# Altium schematic sheet sdflowmetercpu.SchDoc(sdflowmetercpu)
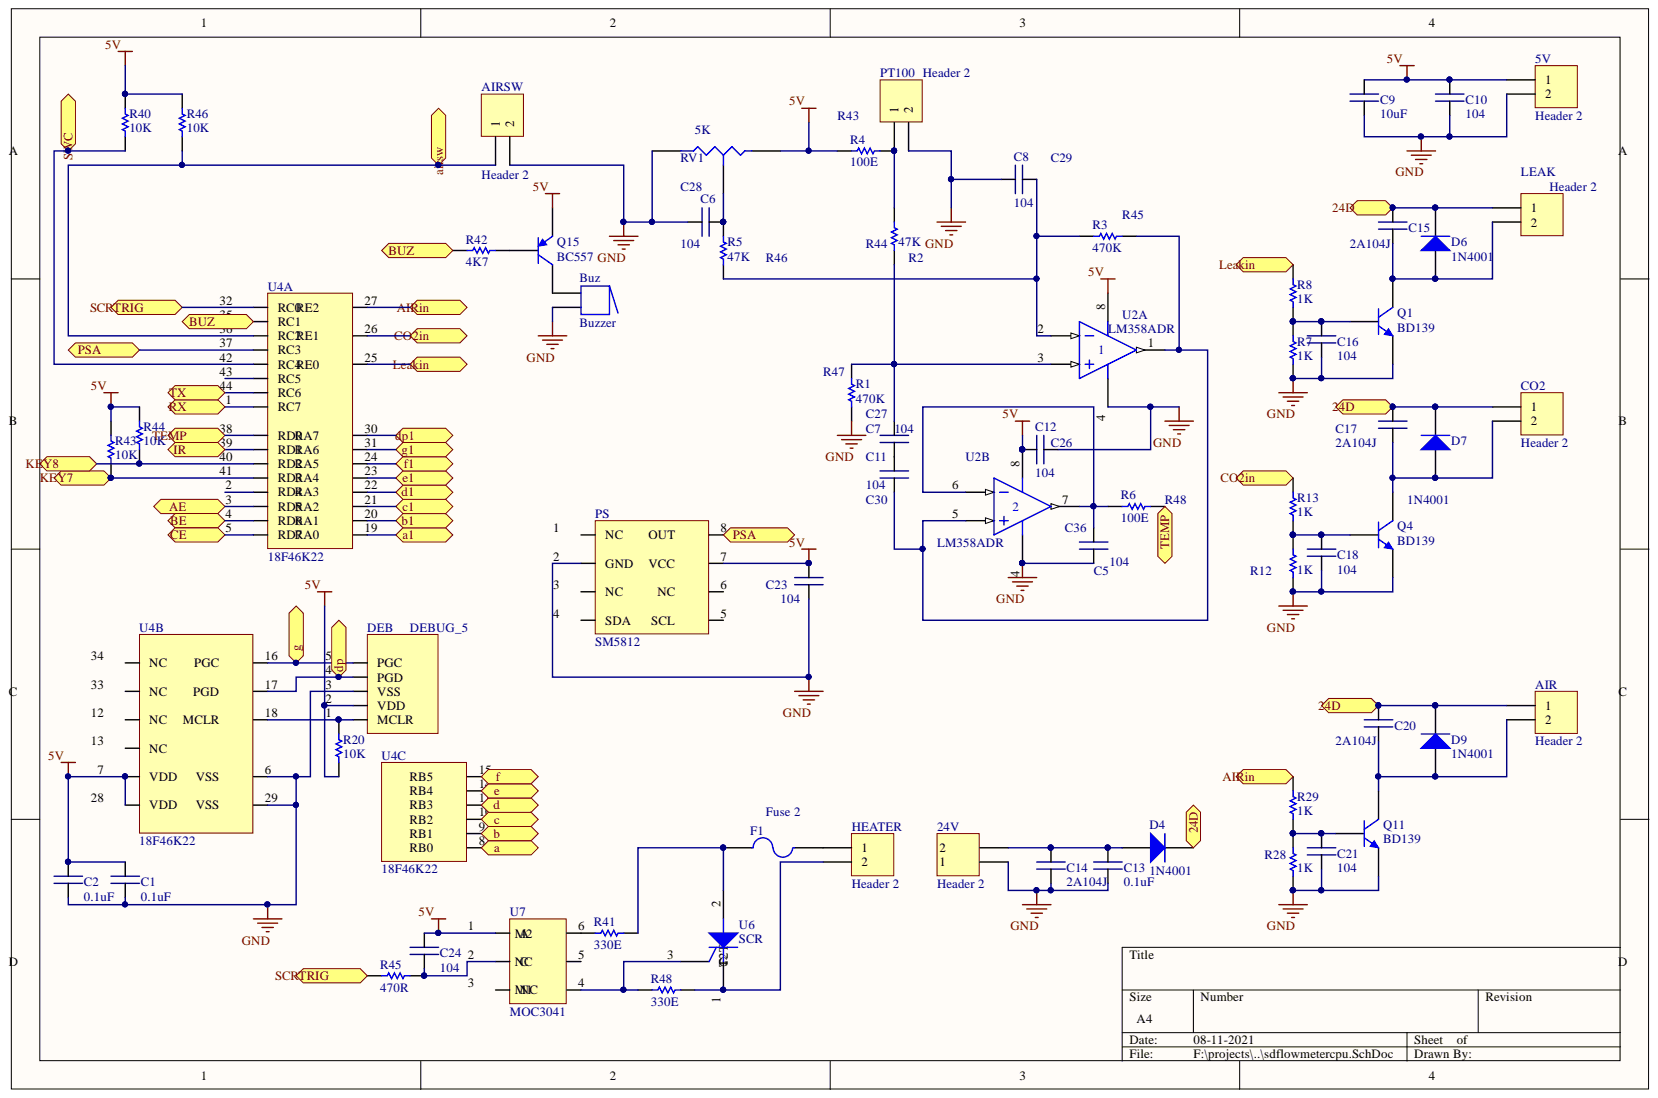
[diagram p0: sdflowmetercpu.SchDoc]

## Parts
5V  Header 2  [green2_5.08]
24V  Header 2  [green2_5.08]
AIR  Header 2  [green2_5.08]
AIRSW  Header 2  [green2_5.08]
Buz  Buzzer  [BUZ]
C1  0.1uF  [0805]
C2  0.1uF  [0805]
C5  104  [0805]
C6  104  [0805]
C7  104  [0805]
C8  104  [0805]
C9  10uF  [0805]
C10  104  [0805]
C11  104  [0805]
C12  104  [0805]
C13  0.1uF  [0805]
C14  2A104J  [caprad6.5]
C15  2A104J  [caprad6.5]
C16  104  [0805]
C17  2A104J  [caprad6.5]
C18  104  [0805]
C20  2A104J  [caprad6.5]
C21  104  [0805]
C23  104  [0805]
C24  104  [0805]
CO2  Header 2  [green2_5.08]
D4  1N4001  [SMB]
D6  1N4001  [SMB]
D7  1N4001  [SMB]
D9  1N4001  [SMB]
DEB  DEBUG_5  [rel5pin]
F1  Fuse 2  [Fuse1]
HEATER  Header 2  [green2_5.08]
LEAK  Header 2  [green2_5.08]
PS  SM5812  [DIP8_6]
PT100  Header 2  [green2_5.08]
Q1  BD139  [TO-220-AB]
Q4  BD139  [TO-220-AB]
Q11  BD139  [TO-220-AB]
Q15  BC557  [SOT-23]
R1  470K  [0805]
R2  47K  [0805]
R3  470K  [0805]
R4  100E  [0805]
R5  47K  [0805]
R6  100E  [0805]
R7  1K  [0805]
R8  1K  [0805]
R12  1K  [0805]
R13  1K  [0805]
R20  10K  [0805]
R28  1K  [0805]
R29  1K  [0805]
R40  10K  [0805]
R41  330E  [0805]
R42  4K7  [0805]
R43  10K  [0805]
R44  10K  [0805]
R45  470R  [0805]
R46  10K  [0805]
R48  330E  [0805]
RV1  5K  [rel3pin]
U2  LM358ADR  [D008_L]  (parts A,B)
U4  18F46K22  [TQFP44]  (parts A,B,C)
U6  SCR  [TO-220-AB]
U7  MOC3041  [SOIC6]

## Ports
24D, A, A1, AE, AIRIN, AIRSW, B, B1, BE, BUZ, C, C1, CE, CO2IN, D, D1, DP, DP1, E, E1, F, F1, G, G1, IR, KEY7, KEY8, LEAKIN, PSA, RX, SCRTRIG, SWC, TEMP, TX

## Nets
5V: 5V.1 C1.1 C2.1 C9.1 C10.1 C12.1 C23.1 C24.1 DEB.2(VDD) PS.7 Q15.2 R4.1 R20.1 R40.2 R43.2 R44.2 R46.2 RV1.1 U2.8 U4.7(VDD) U4.28(VDD) U7.1(M2)
GND: 5V.2 24V.1 AIRSW.2 Buz.2 C1.2 C2.2 C5.1 C6.2 C8.2 C9.2 C10.2 C12.2 C13.2 C14.2 C16.2 C18.2 C21.2 C23.2 DEB.3(VSS) PS.2(GND) PT100.2 Q1.3 Q4.3 Q11.3 R1.1 R7.1 R12.1 R28.1 RV1.2 U2.4 U4.6 U4.29
24D: AIR.1 C15.1 C17.1 C20.1 CO2.1 D4.2 D6.2 D7.2 D9.2 LEAK.1 ; port 24D
AE: U4.3(RD5) ; port AE
BE: U4.4(RD6) ; port BE
BUZ: R42.1 U4.35(RC1) ; port BUZ
CE: U4.5(RD7) ; port CE
IR: U4.39(RD1) ; port IR
KEY7: R43.1 U4.41(RD3) ; port KEY7
KEY8: R44.1 U4.40(RD2) ; port KEY8
PSA: PS.8 U4.37(RC3) ; port PSA
RX: U4.1(RC7) ; port RX
SCRTRIG: R45.1 U4.32(RC0) ; port SCRTRIG
SWC: R40.1 U4.42(RC4) ; port SWC
TEMP: R6.2 U4.38(RD0) ; port TEMP
TX: U4.44(RC6) ; port TX
Net24V_2: 24V.2 C13.1 C14.1 D4.1
NetAIR_2: AIR.2 C20.2 D9.1 Q11.2
NetAIRSW_1: AIRSW.1 R46.1 U4.36(RC2) ; port AIRSW
NetBuz_1: Buz.1 Q15.3
NetC5_2: C5.2 R6.1 U2.6 U2.7
NetC6_1: C6.1 R5.2 RV1.3
NetC7_1: C7.1 R1.2 R2.2 U2.3
NetC7_2: C7.2 C11.2
NetC8_1: C8.1 R3.1 R5.1 U2.2
NetC11_1: C11.1 R3.2 U2.1 U2.5
NetC15_2: C15.2 D6.1 LEAK.2 Q1.2
NetC16_1: C16.1 Q1.1 R7.2 R8.2
NetC17_2: C17.2 CO2.2 D7.1 Q4.2
NetC18_1: C18.1 Q4.1 R12.2 R13.1
NetC21_1: C21.1 Q11.1 R28.2 R29.1
NetC24_2: C24.2 R45.2 U7.2(NC)
NetDEB_1: DEB.1(MCLR) R20.2 U4.18
NetDEB_4: DEB.4(PGD) U4.17 ; port DP
NetDEB_5: DEB.5(PGC) U4.16 ; port G
NetF1_1: F1.1 R41.2 U6.2
NetF1_2: F1.2 HEATER.1
NetHEATER_2: HEATER.2 R48.2 U6.1
NetPT100_1: PT100.1 R2.1 R4.2
NetQ15_1: Q15.1 R42.2
NetR8_1: R8.1 U4.25 ; port LEAKIN
NetR13_2: R13.2 U4.26 ; port CO2IN
NetR29_2: R29.2 U4.27 ; port AIRIN
NetR41_1: R41.1 U7.6
NetR48_1: R48.1 U6.3 U7.4
NetU4_8: U4.8 ; port A
NetU4_9: U4.9 ; port B
NetU4_10: U4.10 ; port C
NetU4_11: U4.11 ; port D
NetU4_14: U4.14 ; port E
NetU4_15: U4.15 ; port F
NetU4_19: U4.19 ; port A1
NetU4_20: U4.20 ; port B1
NetU4_21: U4.21 ; port C1
NetU4_22: U4.22 ; port D1
NetU4_23: U4.23 ; port E1
NetU4_24: U4.24 ; port F1
NetU4_30: U4.30 ; port DP1
NetU4_31: U4.31 ; port G1

Not connected: PS 1(NC) 3(NC) 4(SDA) 5 6; U4 2(RD4) 12(NC) 13(NC) 33(NC) 34(NC) 43(RC5); U7 3(M1) 5

## Library data of the parts
5V 24V AIR AIRSW CO2 HEATER LEAK PT100 = Header 2: Header, 2-Pin; Component Kind: Standard; LatestRevisionDate: 17-Jul-2002; LatestRevisionNote: Re-released for DXP Platform.; Library Name: Miscellaneous Connectors.IntLib; Signal Integrity: Connector; Publisher: Altium Limited
Buz = Buzzer: Magnetic Transducer Buzzer; Component Kind: Standard; ComponentLink1Description: Manufacturer Link; ComponentLink1URL: http://www.megastar.com/linecard/buzzmode/index.php; DatasheetDocument: 17-Dec-2003; LatestRevisionDate: 17-Dec-2003; LatestRevisionNote: Schematic revised, PCB Footprint replaced.; PackageReference: ABSM-1574; Published: 24-Mar-1999; Library Name: myaltiumsch.SchLib; Publisher: Altium Limited
C1 C2 C5-C18 C20 C21 C23 C24 = cap0805: Component Kind: Standard; Library Name: myaltiumsch.SchLib
D4 D6 D7 D9 = Diode 1N4001: 1 Amp General Purpose Rectifier; Code_JEDEC: DO-204-AL; Component Kind: Standard; ComponentLink1Description: Manufacturer Link; ComponentLink1URL: http://www.fairchildsemi.com/; ComponentLink2Description: Datasheet; ComponentLink2URL: http://www.fairchildsemi.com/ds/1N%2F1N4001.pdf; DatasheetDocument: 1998; LatestRevisionDate: 17-Jul-2002; LatestRevisionNote: Re-released for DXP Platform.; Library Name: Miscellaneous Devices.IntLib; PackageDocument: Aug-1999; PackageReference: DO-41; Published: 8-Jun-2000; Signal Integrity: 1N4001; Simulation: 1N4001; Publisher: Altium Limited
F1 = Fuse 2: Fuse; Component Kind: Standard; LatestRevisionDate: 17-Jul-2002; LatestRevisionNote: Re-released for DXP Platform.; Library Name: Miscellaneous Devices.IntLib; PackageReference: PIN-W2/E2.8; Published: 8-Jun-2000; Simulation: FUSE; Publisher: Altium Limited
Q1 Q4 Q11 = NPN_SOT32: NPN Bipolar Transistor; Code_JEDEC: TO-226-AA; Component Kind: Standard; LatestRevisionDate: 17-Jul-2002; LatestRevisionNote: Re-released for DXP Platform.; PackageReference: TO-226; Published: 8-Jun-2000; Signal Integrity: NPN; Simulation: NPN; Library Name: myaltiumsch.SchLib; Publisher: Altium Limited
Q15 = PNP: PNP Bipolar Transistor; Component Kind: Standard; LatestRevisionDate: 29-May-2009; LatestRevisionNote: IPC-7351 Footprint Added.; PackageDescription: 3-Pin SOT-23 Package 0.95 mm Pitch, 2.4 mm Lead Span; PackageReference: SOT-23B; PackageVersion: Aug-1999; Published: 8-Jun-2000; Signal Integrity: PNP; Simulation: PNP; Library Name: myaltiumsch.SchLib; Publisher: Altium Limited
R1-R8 R12 R13 R20 R28 R29 R40-R46 R48 = RES: Resistor; Component Kind: Standard; LatestRevisionDate: 17-Jul-2002; LatestRevisionNote: Re-released for DXP Platform.; PackageReference: AXIAL-0.5; Published: 8-Jun-2000; Signal Integrity: Res Semi; Simulation: RES; Library Name: myaltiumsch.SchLib; Publisher: Altium Limited
RV1 = Res Tap: Tapped Resistor; Set Position: 0.5; Sim Note: Enter the Resistance value and the set point into parameter fields.; Value: 1K; Component Kind: Standard; LatestRevisionDate: 17-Jul-2002; LatestRevisionNote: Re-released for DXP Platform.; Library Name: Miscellaneous Devices.IntLib; PackageReference: VR3; Published: 24-Mar-1999; Signal Integrity: Res Tap; Simulation: POT; Publisher: Altium Limited
U2 = LM358ADR: Dual Operational Amplifier; Code_IPC: SOIC127P600-8; Component Kind: Standard; ComponentLink1Description: Manufacturer Link; ComponentLink1URL: http://www.ti.com/; ComponentLink2Description: Datasheet; ComponentLink2URL: http://www-s.ti.com/sc/ds/lm358.pdf; DatasheetVersion: Aug-1988; LatestRevisionDate: 13-Apr-2006; LatestRevisionNote: IPC-7351 Footprint Added.; Library Name: TI Operational Amplifier.IntLib; PackageDescription: 8-Pin Small Outline Integrated Circuit 1.27 mm Pitch; PackageReference: D008; PackageVersion: Jan-1998; PCB3D: D008; Published: 15-Apr-2002; Publisher: Altium Limited
U6 = BT136: Silicon Controlled Rectifier; Code_JEDEC: TO-220-AB; Component Kind: Standard; LatestRevisionDate: 17-Jul-2002; LatestRevisionNote: Re-released for DXP Platform.; PackageReference: TO-220-AB; Published: 8-Jun-2000; Simulation: SCR; Library Name: myaltiumsch.SchLib; Publisher: Altium Limited
DEB = DEBUG_5: Component Kind: Standard; Library Name: myaltiumsch.SchLib
PS = SM5812: Component Kind: Standard; Library Name: myaltiumsch.SchLib
U4 = 18F46K22: Component Kind: Standard; Library Name: myaltiumsch.SchLib
U7 = MOC3041: Component Kind: Standard; Library Name: myaltiumsch.SchLib
